# Altium schematic sheet CURRENT SOURCES.SchDoc("CURRENT SOURCES")
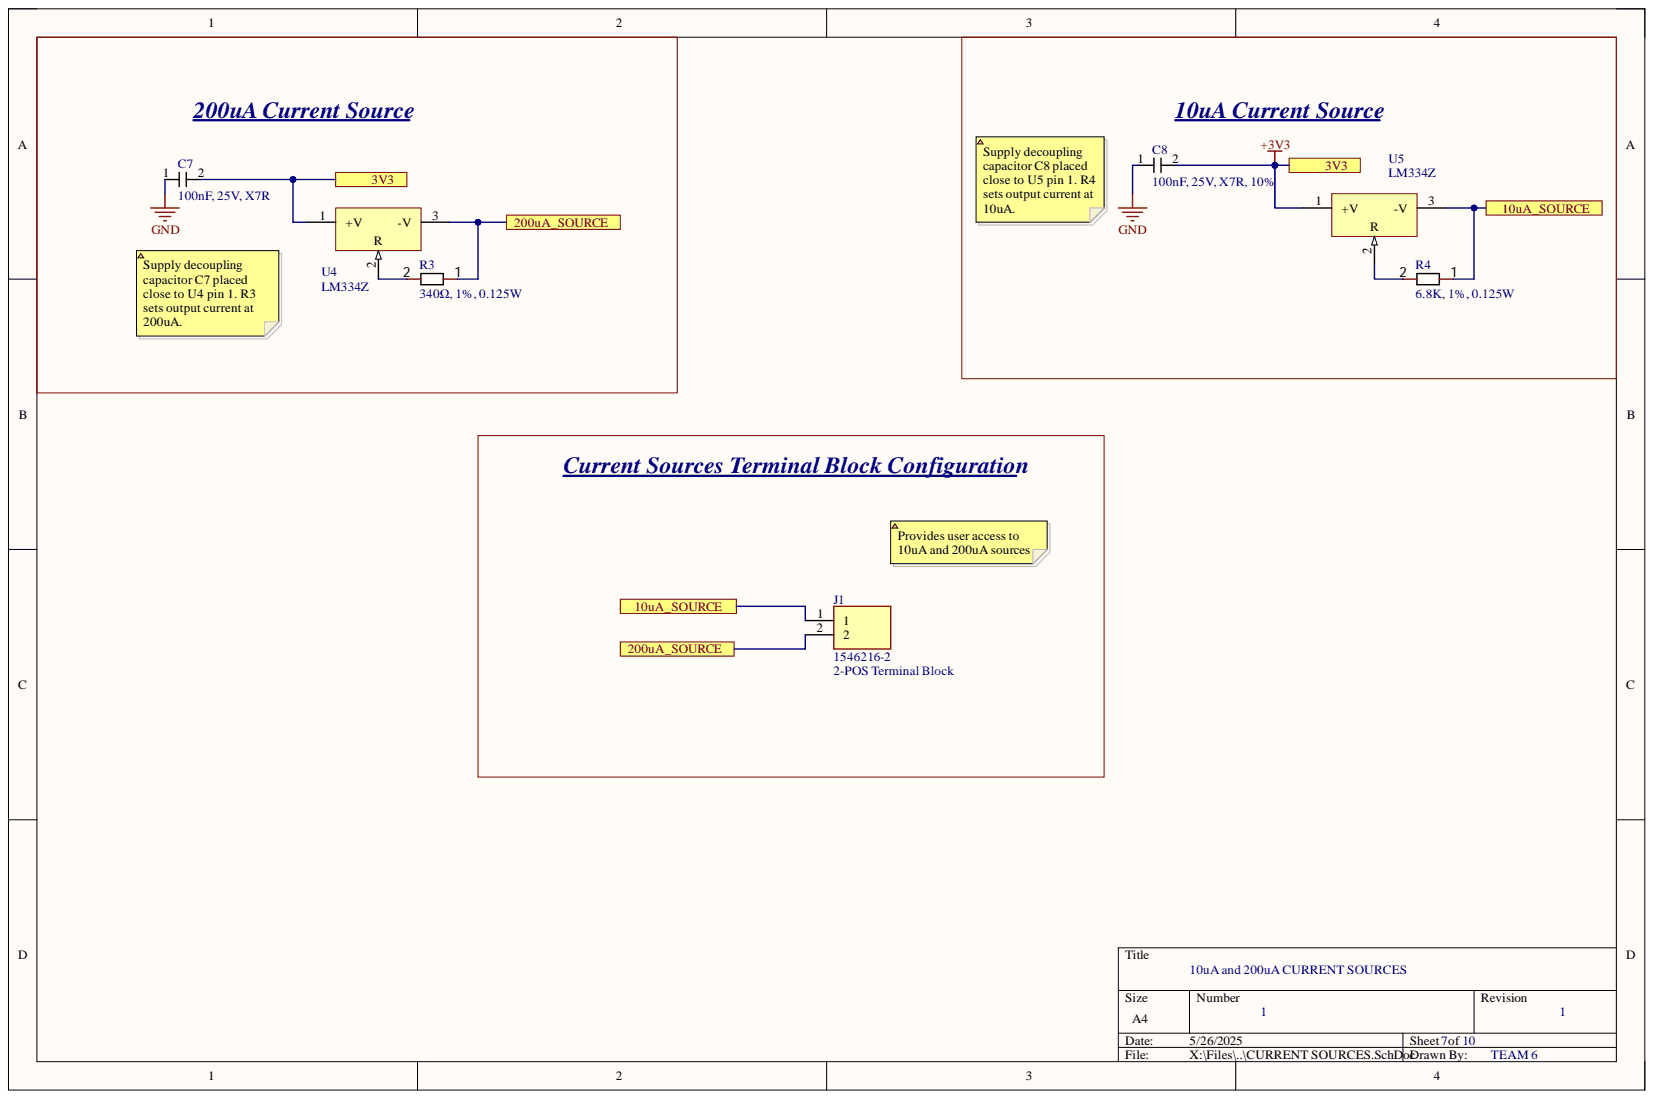
[diagram p0: CURRENT SOURCES.SchDoc]

## Parts
C7  100nF, 25V, X7R  [RC0805N_YAG]
C8  100nF, 25V, X7R, 10%  [RC0805N_YAG]
J1  2-POS Terminal Block  [SHDR2W100P0X500_1X2_1000X820X1000P]
R3  340Ω, 1%, 0.125W  [0805_R]
R4  6.8K, 1%, 0.125W  [0805_R]
U4  LM334Z  [Z03A]
U5  LM334Z  [Z03A]

## Ports
3V3, 10uA_SOURCE, 200uA_SOURCE

## Nets
GND: C7.1 C8.1
10uA_SOURCE: J1.1 R4.1 U5.3 ; port 10uA_SOURCE
200uA_SOURCE: J1.2 R3.1 U4.3 ; port 200uA_SOURCE
+3V3: C7.2 C8.2 U4.1 U5.1 ; port 3V3
NetR3_2: R3.2 U4.2
NetR4_2: R4.2 U5.2

## Library data of the parts
C7 C8 = CAP 103 10nF 1206: Component Kind: Standard; Library Name: Schlib1.SchLib
J1 = 1546216-2: Connector 1546216-2; Manufacturer_Name: TE Connectivity; Arrow Part Number: 1546216-2; Arrow Price/Stock: https://www.arrow.com/en/products/1546216-2/te-connectivity?region=nac; Component Kind: Standard; Datasheet Link: https://www.te.com/commerce/DocumentDelivery/DDEController?Action=srchrtrv&DocNm=1-1773458-1_EURO_STYLE_QRG&DocType=Data%20Sheet&DocLang=English&PartCntxt=1546216-2&DocFormat=pdf; Height: 10mm; Manufacturer_Part_Number: 1546216-2; Mouser Part Number: 571-1546216-2; Mouser Price/Stock: https://www.mouser.co.uk/ProductDetail/TE-Connectivity/1546216-2?qs=Lxq28tKK6BMEq1xb2m0gjg%3D%3D
R3 R4 = 0805-R: Component Kind: Standard; Library Name: Product-PCB.SchLib
U4 U5 = CMP-0063-00062-2: 3-Terminal Adjustable Current Source, 3-pin TO-92; Manufacturer: National Semiconductor; CompType: MiscPower; PackageTopMarking: NSXYTT*LM334*Z; PowerWise: N; Code_JEDEC: TO-226-AA; Component Kind: Standard; ComponentLink1Description: LM334 Datasheet; ComponentLink1URL: http://www.national.com/ds/LM/LM334.pdf; ComponentLink2Description: LM334 Web Page; ComponentLink2URL: http://www.national.com/pf/LM/LM334.html; ComponentLink3Description: Z03A Package Page; ComponentLink3URL: http://www.national.com/packaging/folders/z03a.html; DatasheetVersion: Mar-2005; Library Name: Altium Content Vault; PackageDescription: TO-92, Molded, 3 Lead; PackageReference: Z03A; PackageVersion: revG, Sep-2000; PinCount: 3; RevisionNote: Component URLs added; RoHS: N
